# Altium schematic sheet Main.SchDoc
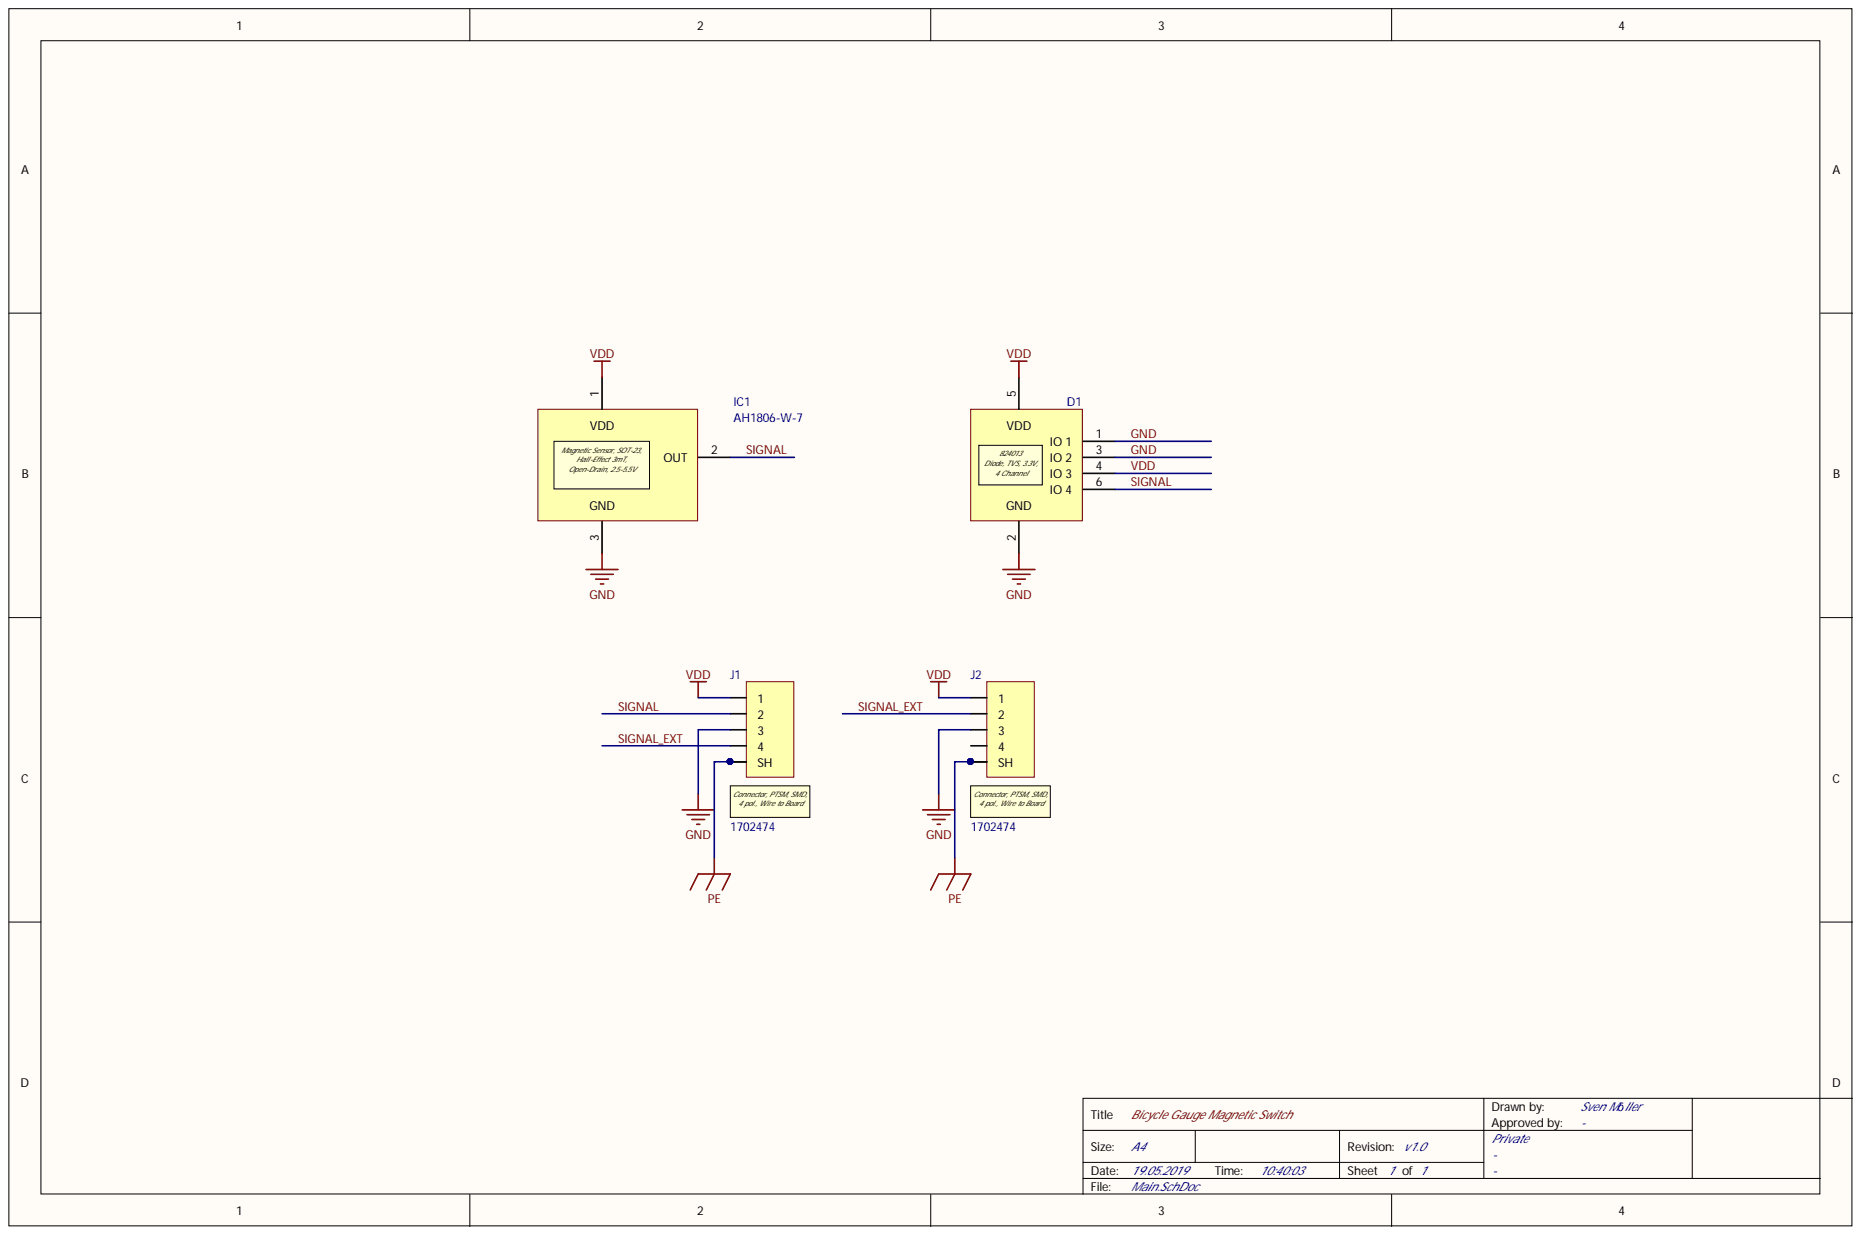
[diagram p0: Main.SchDoc]

## Parts
D1  824013  [SOT-23-6]
IC1  AH1806-W-7  [SOT-23]
J1  1702474  [1702474]
J2  1702474  [1702474]

## Nets
PE: J1.SH1(SH) J1.SH2 J2.SH1(SH) J2.SH2
GND: D1.1(IO_1) D1.2 D1.3(IO_2) IC1.3 J1.3 J2.3
SIGNAL: D1.6(IO_4) IC1.2 J1.2
SIGNAL_EXT: J1.4 J2.2
VDD: D1.4(IO_3) D1.5 IC1.1 J1.1 J2.1

Not connected: J2 4

## Library data of the parts
D1 = 824013: Diode, TVS, 3.3V, 4 Channel; Manufacturer: Würth Electronics; Component Kind: Standard; ComponentLink1Description: Datasheet; ComponentLink1URL: P:/824013.pdf; MPN: 824013; Supplier 1: Digi-Key; Supplier 2: Farnell; Supplier 3: Mouser; Supplier 4: RSComponents; Supplier Part Number 1: 732-4466-1-ND; Supplier Part Number 2: 1825869; Supplier Part Number 3: 710-824013; Supplier Part Number 4: 7546222; Library Name: Createc Circuitstudio Library.IntLib
IC1 = AH1806-W-7: Magnetic Sensor, SOT-23, Hall-Effect 3mT, Open-Drain, 2.5-5.5V; Manufacturer: Diodes Inc.; Component Kind: Standard; ComponentLink1Description: Datasheet; ComponentLink1URL: https://www.diodes.com/assets/Datasheets/AH1806.pdf; Library Name: Schlib1.SchLib; MPN: AH1806-W-7; Supplier 1: Digi-Key; Supplier 2: Farnell; Supplier 3: Mouser; Supplier Part Number 1: AH1806-W-7DICT-ND; Supplier Part Number 2: -; Supplier Part Number 3: 621-AH1806-W-7
J1 J2 = 1702474: Connector, PTSM, SMD, 4 pol., Wire to Board; Manufacturer: Phoenix Contact; Component Kind: Standard; ComponentLink1Description: Datasheet; ComponentLink1URL: P:/1702474.pdf; ComponentLink3Description: Drawing; ComponentLink3URL: P:/pxc_1702474_00_PTSM-0-5-4-2-5-H-SMD-R24_FAM.pdf; Library Name: Schlib1.SchLib; MPN: 1702474; Supplier 1: Digi-Key; Supplier 2: Farnell; Supplier 3: Mouser; Supplier 4: RSComponents; Supplier Part Number 1: 277-2338-1-ND; Supplier Part Number 2: -; Supplier Part Number 3: 651-1702474; Supplier Part Number 4: 804-5449
